# Altium schematic sheet Battery_BMS.SchDoc("Battery & BMS")
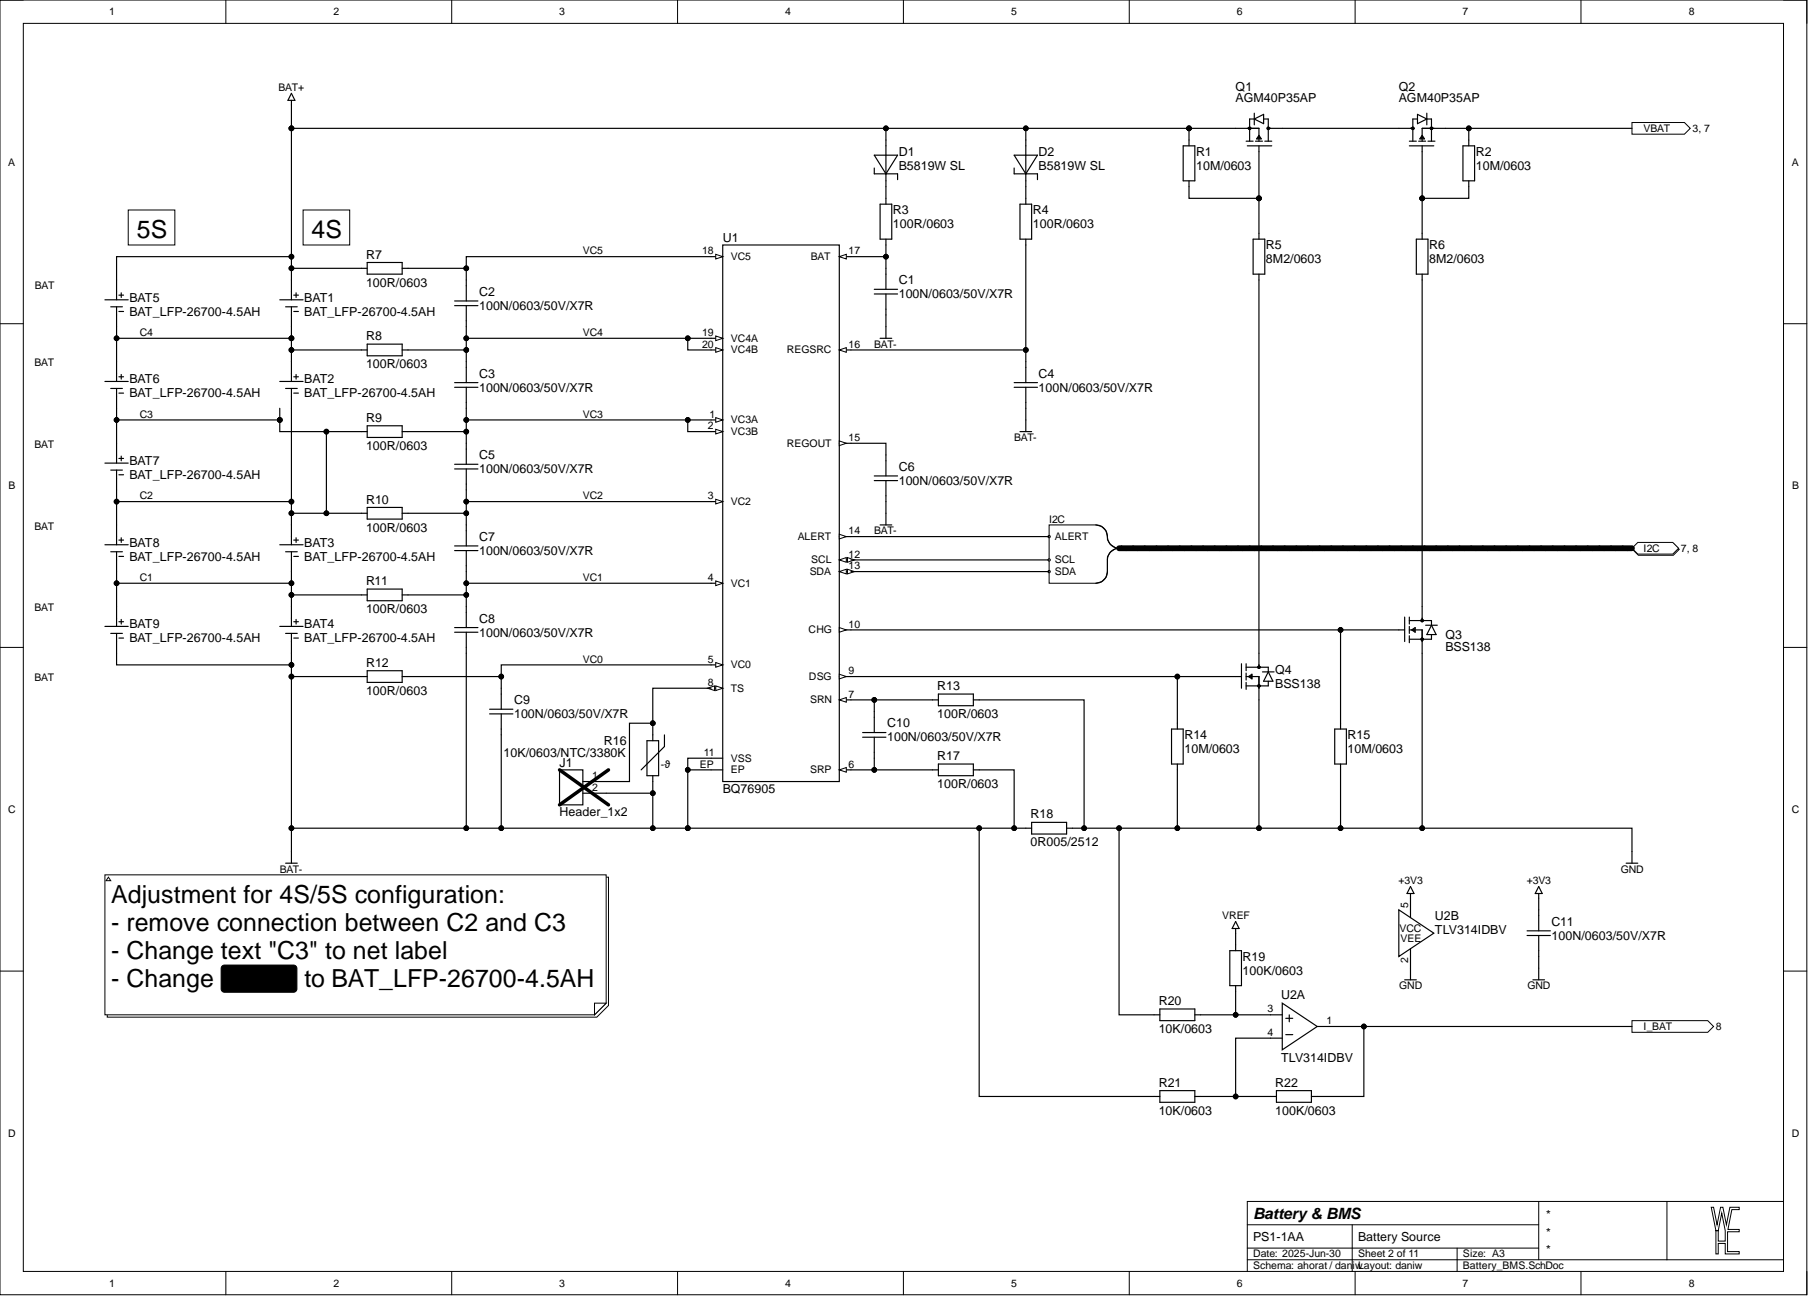
[diagram p0: Battery_BMS.SchDoc]

## Parts
BAT1  BAT_LFP-26700-4.5AH  [BAT-26700-PS]
BAT2  BAT_LFP-26700-4.5AH  [BAT-26700-PS]
BAT3  BAT_LFP-26700-4.5AH  [BAT-26700-PS]
BAT4  BAT_LFP-26700-4.5AH  [BAT-26700-PS]
C1  100N/0603/50V/X7R  [CAP0603_X7R]
C2  100N/0603/50V/X7R  [CAP0603_X7R]
C3  100N/0603/50V/X7R  [CAP0603_X7R]
C4  100N/0603/50V/X7R  [CAP0603_X7R]
C5  100N/0603/50V/X7R  [CAP0603_X7R]
C6  100N/0603/50V/X7R  [CAP0603_X7R]
C7  100N/0603/50V/X7R  [CAP0603_X7R]
C8  100N/0603/50V/X7R  [CAP0603_X7R]
C9  100N/0603/50V/X7R  [CAP0603_X7R]
C10  100N/0603/50V/X7R  [CAP0603_X7R]
C11  100N/0603/50V/X7R  [CAP0603_X7R]
D1  B5819W SL  [SOD3718X125]
D2  B5819W SL  [SOD3718X125]
J1  Header_1x2  [HEAD_254_1X2]
Q1  AGM40P35AP  [SON065P330X330X075-5-TH245X175]
Q2  AGM40P35AP  [SON065P330X330X075-5-TH245X175]
Q3  BSS138  [SOT2395P280X125-3-SE]
Q4  BSS138  [SOT2395P280X125-3-SE]
R1  10M/0603  [R0603-0.40-WW]
R2  10M/0603  [R0603-0.40-WW]
R3  100R/0603  [R0603-0.40-WW]
R4  100R/0603  [R0603-0.40-WW]
R5  8M2/0603  [R0603-0.40-WW]
R6  8M2/0603  [R0603-0.40-WW]
R7  100R/0603  [R0603-0.40-WW]
R8  100R/0603  [R0603-0.40-WW]
R9  100R/0603  [R0603-0.40-WW]
R10  100R/0603  [R0603-0.40-WW]
R11  100R/0603  [R0603-0.40-WW]
R12  100R/0603  [R0603-0.40-WW]
R13  100R/0603  [R0603-0.40-WW]
R14  10M/0603  [R0603-0.40-WW]
R15  10M/0603  [R0603-0.40-WW]
R16  10K/0603/NTC/3380K  [R0603-0.40-WW]
R17  100R/0603  [R0603-0.40-WW]
R18  0R005/2512  [R2512-0.65-WW]
R19  100K/0603  [R0603-0.40-WW]
R20  10K/0603  [R0603-0.40-WW]
R21  10K/0603  [R0603-0.40-WW]
R22  100K/0603  [R0603-0.40-WW]
U1  BQ76905  [QFN050P350X350X100-20-EP200X200]
U2  TLV314IDBV  [SOT2395P280X125-5-NW]  (parts A,B)

## Ports
I2C, I2C.ALERT, I2C.SCL, I2C.SDA, I_BAT, VBAT

## Nets
+3V3: C11.1 U2.5
BAT+: BAT1.1 D1.2 D2.2 Q1.1,2,3 R1.1 R7.1
BAT-: BAT4.2 C1.2 C4.2 C6.2 C8.2 C9.2 J1.2 R12.1 R16.2 R17.2 R18.1 R21.1 U1.11(VSS) U1.EP
GND: C11.2 Q3.2 Q4.2 R13.2 R14.2 R15.2 R18.2 R20.1 U2.2
VREF: R19.1
C1: BAT3.2 BAT4.1 R11.1
C2: BAT2.2 BAT3.1 R9.1 R10.1
C4: BAT1.2 BAT2.1 R8.1
I_BAT: R22.2 U2.1 ; port I_BAT
VBAT: Q2.1,2,3 R2.1 ; port VBAT
VC0: C9.1 R12.2 U1.5(VC0)
VC1: C7.2 C8.1 R11.2 U1.4(VC1)
VC2: C5.2 C7.1 R10.2 U1.3(VC2)
VC3: C3.2 C5.1 R9.2 U1.1(VC3A) U1.2(VC3B)
VC4: C2.2 C3.1 R8.2 U1.19(VC4A) U1.20(VC4B)
VC5: C2.1 R7.2 U1.18(VC5)
NetC1_1: C1.1 R3.2 U1.17(BAT)
NetC4_1: C4.1 R4.2 U1.16(REGSRC)
NetC6_1: C6.1 U1.15(REGOUT)
NetC10_1: C10.1 R13.1 U1.7(SRN)
NetC10_2: C10.2 R17.1 U1.6(SRP)
NetD1_1: D1.1 R3.1
NetD2_1: D2.1 R4.1
NetJ1_1: J1.1 R16.1 U1.8(TS)
NetQ1_4: Q1.4 R1.2 R5.1
NetQ1_5: Q1.5 Q2.5
NetQ2_4: Q2.4 R2.2 R6.1
NetQ3_1: Q3.1 R15.1 U1.10(CHG)
NetQ3_3: Q3.3 R6.2
NetQ4_1: Q4.1 R14.1 U1.9(DSG)
NetQ4_3: Q4.3 R5.2
NetR19_2: R19.2 R20.2 U2.3
NetR21_2: R21.2 R22.1 U2.4
NetU1_12: U1.12(SCL) ; port I2C
NetU1_13: U1.13(SDA) ; port I2C
NetU1_14: U1.14(ALERT) ; port I2C

## Library data of the parts
BAT1-BAT4 = BAT_LFP-26700-4.5AH: Battery LiFePO4 26700 4.5Ah; Manufacturer: Tenpower; Manufacturer Part Number 1: IFR26700-45HE; Database Table Name: Misc$; Component Kind: Standard; HelpURL: https://www.tenpowercell.com/wp-content/uploads/2023/08/TP-IFR26700-45HE-Product-Datasheet-v1.4.pdf; Manufacturer 1: Tenpower; Part Number: BAT_LFP-26700-4.5AH; Supplier 1: NKON; Supplier Part Number 1: 6097321571573; Library Name: daniw_altium_lib.DbLib
C1-C11 = 100N/0603/50V/X7R: CAP SM 0603 100nF 50V X7R; Manufacturer: GENERIC; Manufacturer 1: YAGEO; Manufacturer Part Number 1: CC0603KPX7R9BB104; Absolute Value: 1E-7; Database Table Name: C$; Eurocircuits GPN: GPC0603104; Component Kind: Standard; HelpURL: https://www.yageo.com/upload/media/product/app/datasheet/mlcc/upy-gphc_x7r_6_3v-to-250v.pdf; JLC Type: Basic; Manufacturer 2: YAGEO; Manufacturer Part Number 2: CC0603MRX7R9BB104; Manufacturer Part Number 3: CC0603KRX7R9BB104; Package: 0603; Part Number: 100N/0603/50V/X7R; Supplier 1: Digi-Key; Supplier 2: Digi-Key; Supplier 3: LCSC; Supplier Part Number 1: 311-3567-1-ND; Supplier Part Number 2: 311-4110-1-ND; Supplier Part Number 3: C14663; Value: 100nF; Library Name: daniw_altium_lib.DbLib
D1 D2 = B5819W SL: 40V 600mV@1A 1A SOD-123 Schottky Barrier Diodes; Manufacturer: GENERIC; Manufacturer 1: SMC Diode Solutions; Database Table Name: D$; Absolute Value: 40V; Component Kind: Standard; HelpURL: https://datasheet.lcsc.com/lcsc/2304140030_Jiangsu-Changjing-Electronics-Technology-Co---Ltd--B5819W-SL_C8598.pdf; JLC Type: Basic; Manufacturer 3: Jiangsu Changjing Electronics Technology Co., Ltd.; Manufacturer Part Number 1: B5819WSL; Manufacturer Part Number 3: B5819W SL; Package: SOD-123; Part Number: B5819W SL; Supplier 1: Digikey; Supplier 3: LCSC; Supplier Part Number 1: 1655-B5819WSLCT-ND; Supplier Part Number 3: C8598; Value: B5819W SL; Library Name: daniw_altium_lib.DbLib
J1 = Header_1x2: Header 100 mil 1x2; Manufacturer: GENERIC; Database Table Name: CON$; System: Connector; Component Kind: Standard; Part Number: Header_1x2; Library Name: daniw_altium_lib.DbLib
Q1 Q2 = AGM40P35AP: 40V 35A 11mΩ@10V,15A 30W 1.7V 1 piece P-channel PDFN(3.3x3.3) MOSFETs ROHS; Manufacturer: AGM-Semi; Database Table Name: T$; Component Kind: Standard; HelpURL: https://www.lcsc.com/datasheet/lcsc_datasheet_2504101957_AGM-Semi-AGM40P35AP_C18221399.pdf; Manufacturer 3: AGM-Semi; Manufacturer Part Number 3: AGM40P35AP; Package: 8-PowerVDFN; Part Number: AGM40P35AP; Supplier 3: LCSC; Supplier Part Number 3: C18221399; Library Name: daniw_altium_lib.DbLib
Q3 Q4 = BSS138: N-Kanal 50 V 220mA (Ta) 350mW (Ta) Oberflächenmontage SOT-23; Manufacturer: GENERIC; Manufacturer 1: ANBON SEMICONDUCTOR (INT'L) LIMITED; Database Table Name: T$; Component Kind: Standard; HelpURL: https://mm.digikey.com/Volume0/opasdata/d220001/medias/docus/3037/BSS138.pdf; JLC Type: Extended; Manufacturer 2: FOSAN; Manufacturer 3: FOSAN; Manufacturer Part Number 1: BSS138; Manufacturer Part Number 2: BSS138; Manufacturer Part Number 3: BSS138; Package: SOT23; Part Number: BSS138; Supplier 1: Digi-Key; Supplier 2: LCSC; Supplier 3: LCSC; Supplier Part Number 1: 4530-BSS138CT-ND; Supplier Part Number 2: C2926186; Supplier Part Number 3: C2926186; Library Name: daniw_altium_lib.DbLib
R1 R2 R14 R15 = 10M/0603: RES SM 0603 10M; Manufacturer: GENERIC; Manufacturer 1: YAGEO; Manufacturer Part Number 1: RC0603FR-1310ML; Absolute Value: 10000000; Database Table Name: R$; Eurocircuits GPN: GPR060310M; Component Kind: Standard; HelpURL: https://www.yageo.com/upload/media/product/productsearch/datasheet/rchip/PYu-RC_Group_51_RoHS_L_12.pdf; JLC Type: Basic; Manufacturer 2: YAGEO; Manufacturer 3: UNI-ROYAL(Uniroyal Elec); Manufacturer Part Number 2: RC0603FR-1010ML; Manufacturer Part Number 3: 0603WAF1005T5E; Package: 0603; Part Number: 10M/0603; Supplier 1: Digi-Key; Supplier 2: Digi-Key; Supplier 3: LCSC; Supplier Part Number 1: 13-RC0603FR-1310MLCT-ND; Supplier Part Number 2: 13-RC0603FR-1010MLCT-ND; Supplier Part Number 3: C7250; Value: 10M; Library Name: daniw_altium_lib.DbLib
R3 R4 R7-R13 R17 = 100R/0603: RES SM 0603 100R; Manufacturer: GENERIC; Manufacturer 1: YAGEO; Manufacturer Part Number 1: RC0603FR-13100RL; Absolute Value: 100; Database Table Name: R$; Eurocircuits GPN: GPR0603100R; Component Kind: Standard; HelpURL: https://www.yageo.com/upload/media/product/productsearch/datasheet/rchip/PYu-RC_Group_51_RoHS_L_12.pdf; Manufacturer 2: YAGEO; Manufacturer 3: UNI-ROYAL(Uniroyal Elec); Manufacturer Part Number 2: RC0603FR-10100RL; Manufacturer Part Number 3: 0603WAF1000T5E; Package: 0603; Part Number: 100R/0603; Supplier 1: Digi-Key; Supplier 2: Digi-Key; Supplier 3: LCSC; Supplier Part Number 1: 13-RC0603FR-13100RLCT-ND; Supplier Part Number 2: 13-RC0603FR-10100RLCT-ND; Supplier Part Number 3: C22775; Value: 100R; Library Name: daniw_altium_lib.DbLib
R5 R6 = 8M2/0603: RES SM 0603 8M2; Manufacturer: GENERIC; Manufacturer 1: YAGEO; Manufacturer Part Number 1: RC0603FR-078M2L; Absolute Value: 8200000; Database Table Name: R$; Eurocircuits GPN: GPR06038M2; Component Kind: Standard; HelpURL: https://www.yageo.com/upload/media/product/app/datasheet/rchip/pyu-rc_group_51_rohs_l.pdf; JLC Type: Extended; Manufacturer 3: FOJAN; Manufacturer Part Number 3: FRC0603J825; Package: 0603; Part Number: 8M2/0603; Supplier 1: Digi-Key; Supplier 3: LCSC; Supplier Part Number 1: 13-RC0603FR-078M2LCT-ND; Supplier Part Number 3: C2933278; Value: 8M2; Library Name: daniw_altium_lib.DbLib
R16 = 10K/0603/NTC/3380K: RES NTC SM 0603 10K; Manufacturer: GENERIC; Manufacturer 1: Murata; Manufacturer Part Number 1: NCU18XH103E60RB; Absolute Value: 10000; Database Table Name: R$; Eurocircuits GPN: GPR060310K; Component Kind: Standard; HelpURL: https://www.murata.com/en-us/api/pdfdownloadapi?cate=luNTCforTempeSenso&partno=NCU18XH103E60RB; JLC Type: Extended; Manufacturer 3: Sunlord; Manufacturer Part Number 3: SDNT1608X103F3380FTF; Package: 0603; Part Number: 10K/0603/NTC/3380K; Supplier 1: Digi-Key; Supplier 3: LCSC; Supplier Part Number 1: 490-18164-1-ND; Supplier Part Number 3: C316397; Value: 10K; Library Name: daniw_altium_lib.DbLib
R18 = 0R005/2512: RES SM 2512 0R005; Manufacturer: GENERIC; Manufacturer 1: Ohmite; Manufacturer Part Number 1: MCS3264R005FER; Absolute Value: 0.005; Database Table Name: R$; Component Kind: Standard; HelpURL: https://www.ohmite.com/assets/docs/res_mcs.pdf?r=false; Manufacturer 3: prosemi; Manufacturer Part Number 3: LMP25MF2P0R005; Package: 2512; Part Number: 0R005/2512; Supplier 1: Digi-Key; Supplier 3: LCSC; Supplier Part Number 1: MCS3264R005FERCT-ND; Supplier Part Number 3: C2937402; Value: 0R005; Library Name: daniw_altium_lib.DbLib
R19 R22 = 100K/0603: RES SM 0603 100K; Manufacturer: GENERIC; Manufacturer 1: YAGEO; Manufacturer Part Number 1: RC0603FR-13100KL; Absolute Value: 100000; Database Table Name: R$; Eurocircuits GPN: GPR0603100K; Component Kind: Standard; HelpURL: https://www.yageo.com/upload/media/product/productsearch/datasheet/rchip/PYu-RC_Group_51_RoHS_L_12.pdf; JLC Type: Basic; Manufacturer 2: YAGEO; Manufacturer 3: UNI-ROYAL(Uniroyal Elec); Manufacturer Part Number 2: RC0603FR-10100KL; Manufacturer Part Number 3: 0603WAF1003T5E; Package: 0603; Part Number: 100K/0603; Supplier 1: Digi-Key; Supplier 2: Digi-Key; Supplier 3: LCSC; Supplier Part Number 1: 13-RC0603FR-13100KLCT-ND; Supplier Part Number 2: 13-RC0603FR-10100KLCT-ND; Supplier Part Number 3: C25803; Value: 100K; Library Name: daniw_altium_lib.DbLib
R20 R21 = 10K/0603: RES SM 0603 10K; Manufacturer: GENERIC; Manufacturer 1: YAGEO; Manufacturer Part Number 1: RC0603FR-1310KL; Absolute Value: 10000; Database Table Name: R$; Eurocircuits GPN: GPR060310K; Component Kind: Standard; HelpURL: https://www.yageo.com/upload/media/product/productsearch/datasheet/rchip/PYu-RC_Group_51_RoHS_L_12.pdf; JLC Type: Basic; Manufacturer 2: YAGEO; Manufacturer 3: UNI-ROYAL(Uniroyal Elec); Manufacturer Part Number 2: RC0603FR-1010KL; Manufacturer Part Number 3: 0603WAF1002T5E; Package: 0603; Part Number: 10K/0603; Supplier 1: Digi-Key; Supplier 2: Digi-Key; Supplier 3: LCSC; Supplier Part Number 1: 13-RC0603FR-1310KLCT-ND; Supplier Part Number 2: 13-RC0603FR-1010KLCT-ND; Supplier Part Number 3: C25804; Value: 10K; Library Name: daniw_altium_lib.DbLib
U1 = BQ76905: BQ76905 2-Series to 5-Series High Accuracy Battery Monitor and Protector for Li-Ion, Li-Polymer, LiFePO4 (LFP), and LTO Battery Packs; Manufacturer: Texas Instruments; Manufacturer Part Number 1: BQ76905RGRR; Database Table Name: Integrated$; Component Kind: Standard; HelpURL: https://www.ti.com/lit/ds/symlink/bq76905.pdf; Manufacturer 1: Texas Instruments; Manufacturer 3: Texas Instruments; Manufacturer Part Number 3: BQ76905RGRR; Part Number: BQ76905; Supplier 1: Digi-Key; Supplier 3: LCSC; Supplier Part Number 1: 296-BQ76905RGRRCT-ND; Supplier Part Number 3: C22394458; Library Name: daniw_altium_lib.DbLib
U2 = TLV314 SOT23: TLV314, 3-MHz, Low-Power, Internal EMI Filter, RRIO, Operational Amplifier; Manufacturer: Texas Instruments; Manufacturer Part Number 1: TLV314IDBVR; Database Table Name: Integrated$; Component Kind: Standard; HelpURL: https://www.ti.com/lit/ds/symlink/tlv4314.pdf; Manufacturer 1: Texas Instruments; Manufacturer 2: Texas Instruments; Manufacturer 3: Texas Instruments; Manufacturer Part Number 2: TLV314IDBVT; Manufacturer Part Number 3: TLV314IDBVR; Package: SOT23-5; Part Number: TLV314 SOT23; Supplier 1: Digi-Key; Supplier 2: Digi-Key; Supplier 3: LCSC; Supplier Part Number 1: 296-46275-1-ND; Supplier Part Number 2: 296-44110-2-ND; Supplier Part Number 3: C133032; Library Name: daniw_altium_lib.DbLib
